# Altium schematic sheet Sheet3.SchDoc
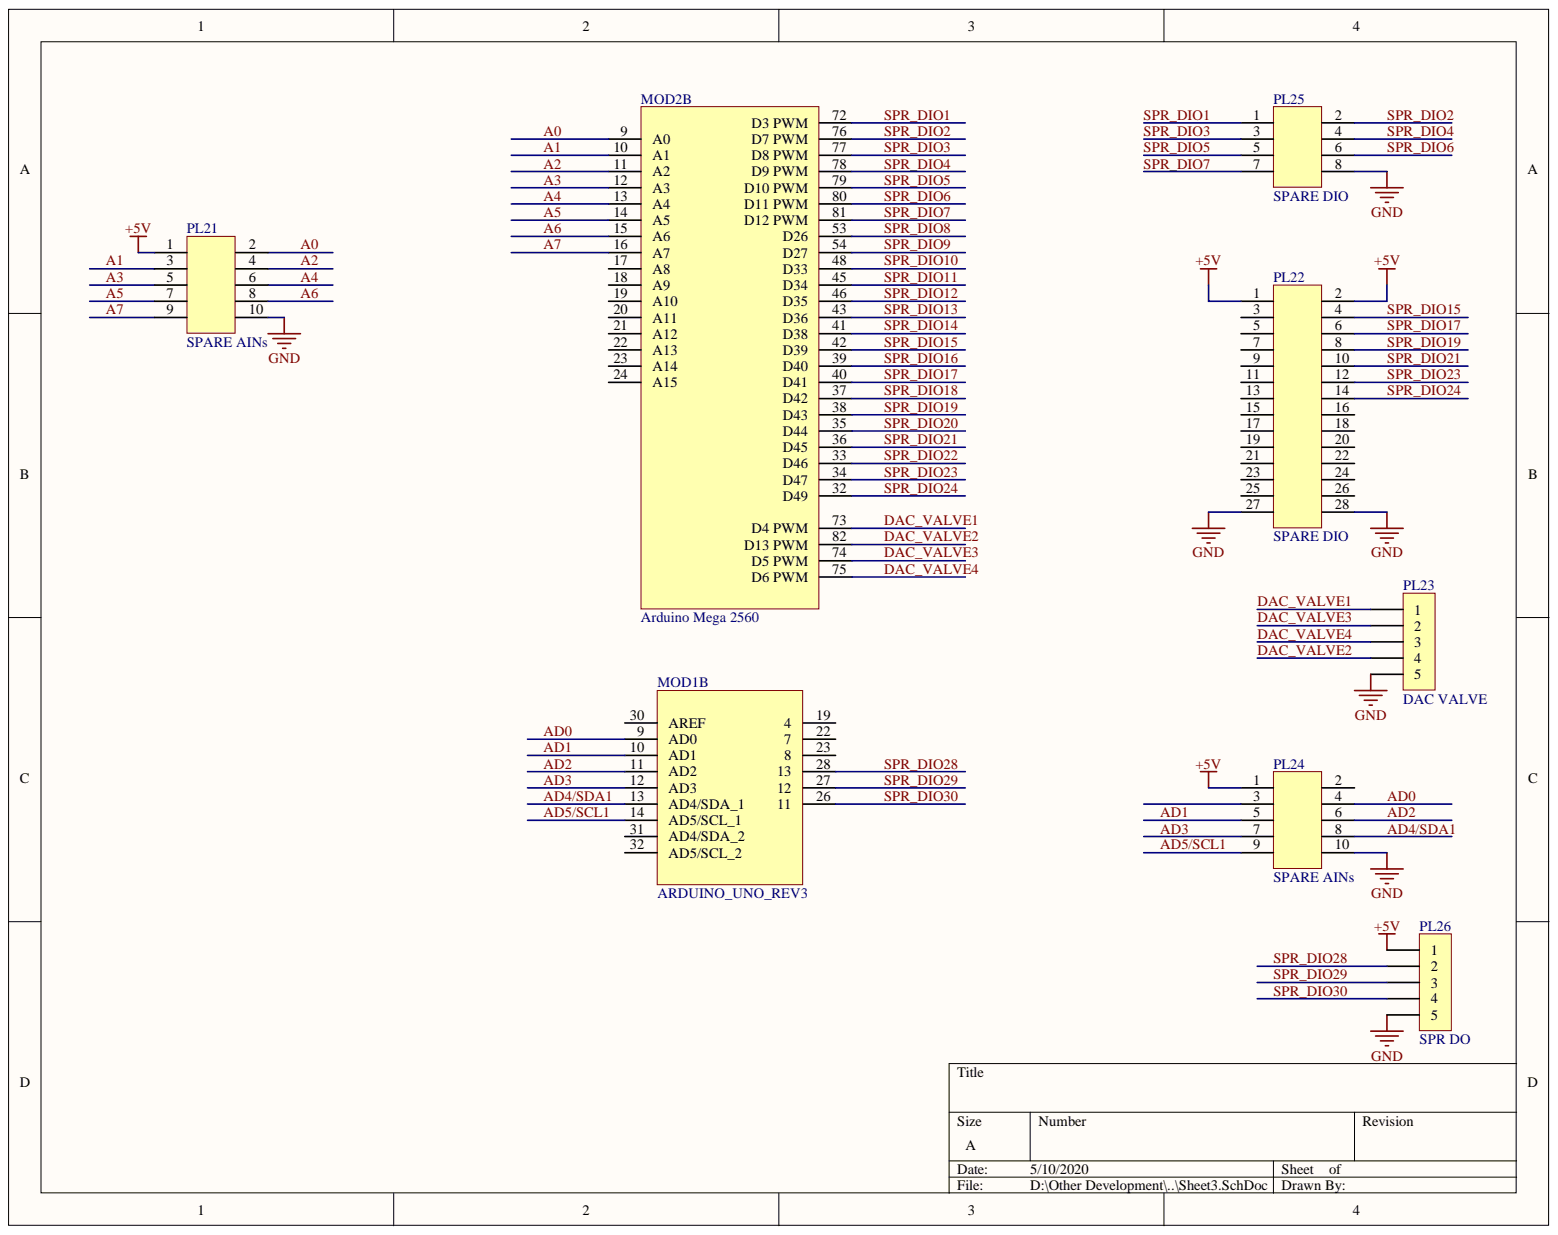
[diagram p0: Sheet3.SchDoc]

## Parts
MOD1  ARDUINO_UNO_REV3  [ARDUINO_UNO_REV3_Arduino_UNO_Flipped]  (part B)
MOD2  Arduino Mega 2560  (part B)
PL21  SPARE AINs  [HDR-10Male-STRT]
PL22  SPARE DIO  [HDR-28Male-STRT]
PL23  DAC VALVE  [JST-XH-5]
PL24  SPARE AINs  [HDR-10Male-STRT]
PL25  SPARE DIO  [HDR-08Male-STRT]
PL26  SPR DO  [HDR-05Male-STRT]

## Nets
+5V: PL21.1 PL22.1 PL22.2 PL24.1 PL26.1
GND: PL21.10 PL22.27 PL22.28 PL23.5 PL24.10 PL25.8 PL26.5
A0: MOD2.9(A0) PL21.2
A1: MOD2.10(A1) PL21.3
A2: MOD2.11(A2) PL21.4
A3: MOD2.12(A3) PL21.5
A4: MOD2.13(A4) PL21.6
A5: MOD2.14(A5) PL21.7
A6: MOD2.15(A6) PL21.8
A7: MOD2.16(A7) PL21.9
AD0: MOD1.9(AD0) PL24.4
AD1: MOD1.10(AD1) PL24.5
AD2: MOD1.11(AD2) PL24.6
AD3: MOD1.12(AD3) PL24.7
AD4/SDA1: MOD1.13(AD4/SDA_1) PL24.8
AD5/SCL1: MOD1.14(AD5/SCL_1) PL24.9
DAC_VALVE1: MOD2.73(D4_PWM) PL23.1
DAC_VALVE2: MOD2.82(D13_PWM) PL23.4
DAC_VALVE3: MOD2.74(D5_PWM) PL23.2
DAC_VALVE4: MOD2.75(D6_PWM) PL23.3
SPR_DIO1: MOD2.72(D3_PWM) PL25.1
SPR_DIO2: MOD2.76(D7_PWM) PL25.2
SPR_DIO3: MOD2.77(D8_PWM) PL25.3
SPR_DIO4: MOD2.78(D9_PWM) PL25.4
SPR_DIO5: MOD2.79(D10_PWM) PL25.5
SPR_DIO6: MOD2.80(D11_PWM) PL25.6
SPR_DIO7: MOD2.81(D12_PWM) PL25.7
SPR_DIO8: MOD2.53(D26)
SPR_DIO9: MOD2.54(D27)
SPR_DIO10: MOD2.48(D33)
SPR_DIO11: MOD2.45(D34)
SPR_DIO12: MOD2.46(D35)
SPR_DIO13: MOD2.43(D36)
SPR_DIO14: MOD2.41(D38)
SPR_DIO15: MOD2.42(D39) PL22.4
SPR_DIO16: MOD2.39(D40)
SPR_DIO17: MOD2.40(D41) PL22.6
SPR_DIO18: MOD2.37(D42)
SPR_DIO19: MOD2.38(D43) PL22.8
SPR_DIO20: MOD2.35(D44)
SPR_DIO21: MOD2.36(D45) PL22.10
SPR_DIO22: MOD2.33(D46)
SPR_DIO23: MOD2.34(D47) PL22.12
SPR_DIO24: MOD2.32(D49) PL22.14
SPR_DIO28: MOD1.28 PL26.2
SPR_DIO29: MOD1.27 PL26.3
SPR_DIO30: MOD1.26 PL26.4

Not connected: MOD1 19 22 23 30(AREF) 31(AD4/SDA_2) 32(AD5/SCL_2); MOD2 17(A8) 18(A9) 19(A10) 20(A11) 21(A12) 22(A13) 23(A14) 24(A15); PL22 3 5 7 9 11 13 15 16 17 18 19 20 21 22 23 24 25 26; PL24 2 3

## Library data of the parts
MOD1 = ARDUINO_UNO_REV3_DEVELOPMENT_BOARD: Plated Through Hole Protoboard Arduino Mega Platform Evaluation Expansion Board; MP: ARDUINO UNO REV3 DEVELOPMENT BOARD; Availability: Unavailable; Component Kind: Standard; Library Name: Schlib1.SchLib; MF: Arduino; Package: None; Price: None; SnapEDA_Link: https://www.snapeda.com/parts/ARDUINO%20UNO%20REV3%20DEVELOPMENT%20BOARD/Arduino/view-part/424299/?ref=snap
PL21 PL24 = HEADER10: 10 PIN HEADER; Component Kind: Standard; Library Name: Schlib1.SchLib
PL22 = HEADER28: 28 PIN HEADER; Component Kind: Standard; Library Name: Schlib1.SchLib
PL23 PL26 = JST XH 2.54_5_1: 5 Pin Connector; Component Kind: Standard; Library Name: Schlib1.SchLib
PL25 = HEADER8: 8 PIN HEADER; Component Kind: Standard; Library Name: Schlib1.SchLib
MOD2 = Arduino Mega 2560: Component Kind: Standard; Library Name: Schlib1.SchLib
